# Altium schematic sheet Sheet1.SchDoc(Sheet1)
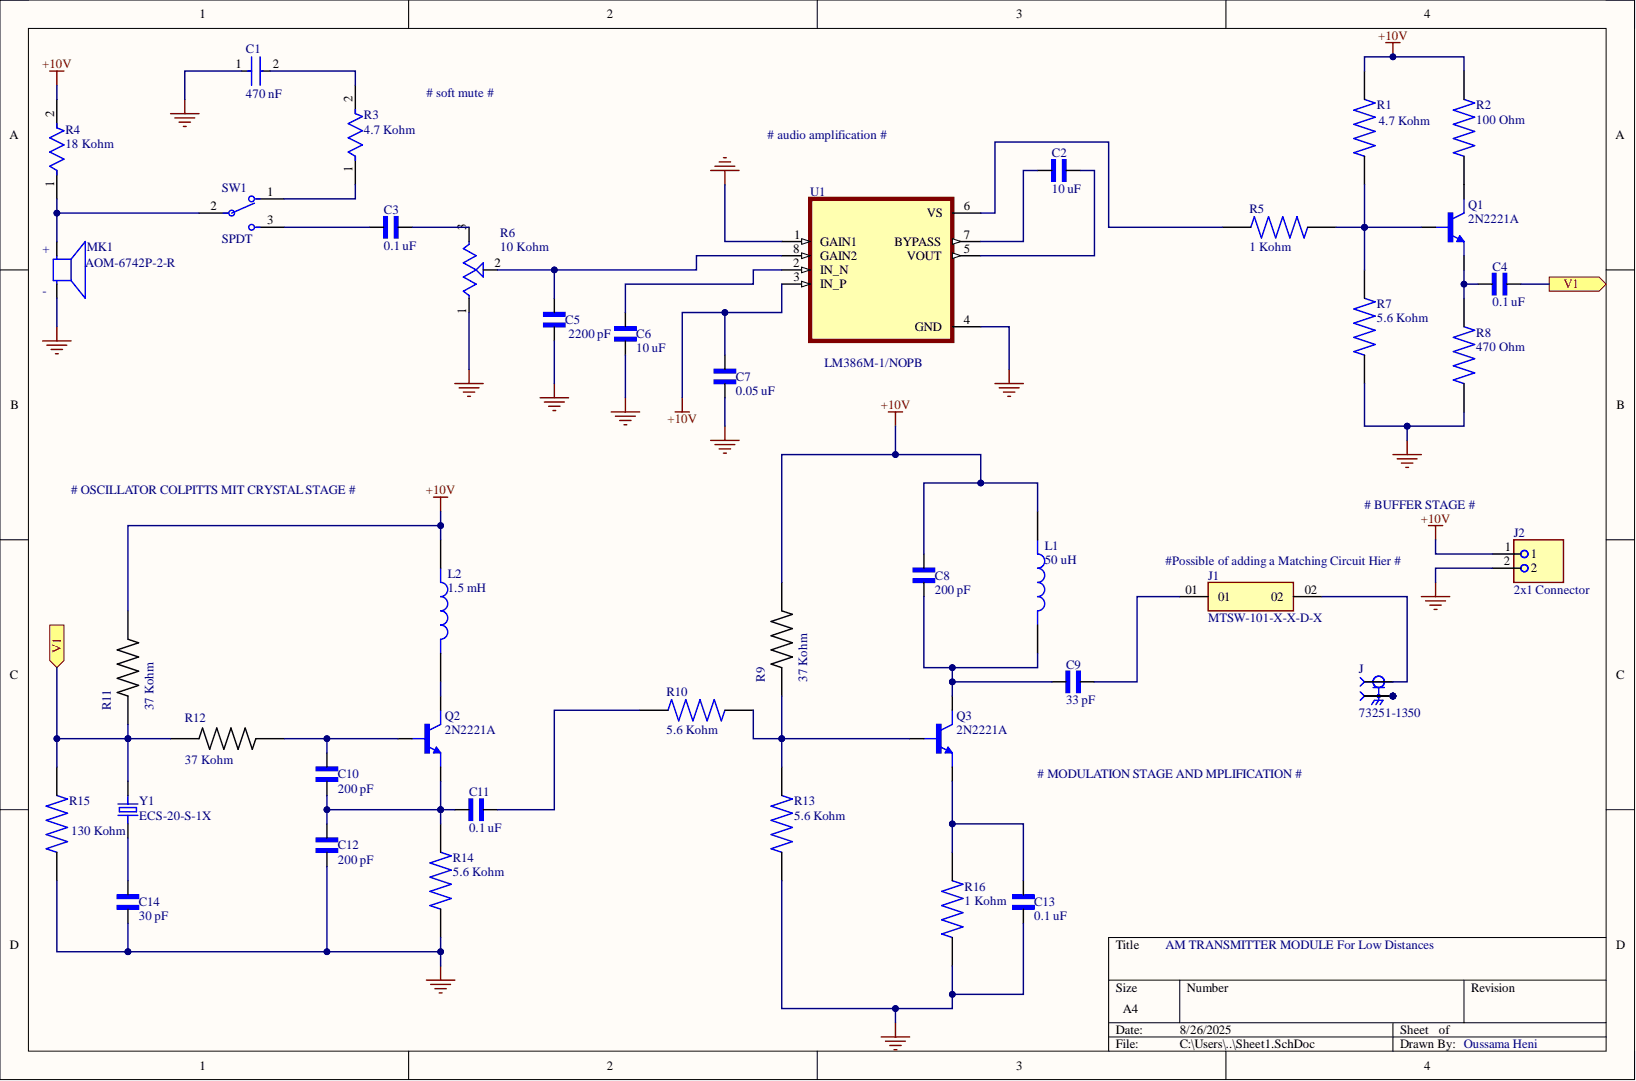
[diagram p0: Sheet1.SchDoc]

## Parts
C1  470 nF  [FP-0603-L_1_6_0_15-W_0_81-IPC_B]
C2  10 uF  [CAPC2012X140N]
C3  0.1 uF  [CAPRR750W60L1260T450H1390]
C4  0.1 uF  [CAPRR750W60L1260T450H1390]
C5  2200 pF  [CAPC1608X90N]
C6  10 uF  [CAPC2012X140N]
C7  0.05 uF  [CAPC3216X94N]
C8  200 pF  [CAPC1608X90N]
C9  33 pF  [CAPC1608X90]
C10  200 pF  [CAPC1608X90N]
C11  0.1 uF  [CAPRR750W60L1260T450H1390]
C12  200 pF  [CAPC1608X90N]
C13  0.1 uF  [CAPRR750W60L1260T450H1390]
C14  30 pF  [CAPC1608X90]
J  73251-1350  [MOLEX_73251-1350]
J1  MTSW-101-X-X-D-X  [SAMTEC_MTSW-101-X-X-D-X]
J2  2x1 Connector  [PHOENIX_1729128]
L1  50 uH  [INDAD2250W80L2000D550]
L2  1.5 mH  [INDAD1680W80L1200D650]
MK1  AOM-6742P-2-R  [MIC_AOM-6742P-2-R]
Q1  2N2221A  [TO-18]
Q2  2N2221A  [TO-18]
Q3  2N2221A  [TO-18]
R1  4.7 Kohm  [RESC3116X65N]
R2  100 Ohm  [RES_ERJPA3F1000V]
R3  4.7 Kohm  [FP-CRCW0603-e3-IPC_C]
R4  18 Kohm  [FP-RT1206-IPC_C]
R5  1 Kohm  [RESC3116X65N]
R6  10 Kohm  [TRIM_PVG3G103C01R00]
R7  5.6 Kohm  [RES_ERJ8ENF5601V]
R8  470 Ohm  [RES_ERJPA2D4700X]
R9  37 Kohm  [*RESC3216X70N]
R10  5.6 Kohm  [RES_ERJ8ENF5601V]
R11  37 Kohm  [*RESC3216X70N]
R12  37 Kohm  [*RESC3216X70N]
R13  5.6 Kohm  [RES_ERJ8ENF5601V]
R14  5.6 Kohm  [RES_ERJ8ENF5601V]
R15  130 Kohm  [RESC3216X65N]
R16  1 Kohm  [RESC3116X65N]
SW1  SPDT  [FP-JS102011JCQN-MFG]
U1  LM386M-1/NOPB  [SOIC127P599X175-8N]
Y1  ECS-20-S-1X  [XTAL_ECS-20-S-1X]

## Ports
V1

## Nets
+10V: C7.2 C8.2 J2.1 L1.1 L2.1 R1.2 R2.2 R4.2 R9.2 R11.2 U1.3(IN_P)
GND: C1.1 C5.1 C6.1 C7.1 C12.1 C13.1 C14.2 J2.2 MK1.N R6.1 R7.2 R8.1 R13.1 R14.1 R15.1 R16.1 U1.1(GAIN1) U1.4(GND)
V1: C4.2 R11.1 R12.1 R15.2 Y1.2 ; port V1
NetC1_2: C1.2 R3.2
NetC2_1: C2.1 U1.7(BYPASS)
NetC2_2: C2.2 U1.5(VOUT)
NetC3_1: C3.1 SW1.3
NetC3_2: C3.2 R6.3
NetC4_1: C4.1 Q1.1 R8.2
NetC5_2: C5.2 R6.2 U1.8(GAIN2)
NetC6_2: C6.2 U1.2(IN_N)
NetC8_1: C8.1 C9.2 L1.2 Q3.3
NetC9_1: C9.1 J1.01
NetC10_1: C10.1 C11.1 C12.2 Q2.1 R14.2
NetC10_2: C10.2 Q2.2 R12.2
NetC11_2: C11.2 R10.1
NetC13_2: C13.2 Q3.1 R16.2
NetC14_1: C14.1 Y1.1
NetJ1_02: J.1 J1.02
NetJ_S1: J.S1 J.S2 J.S3 J.S4
NetL2_2: L2.2 Q2.3
NetMK1_P: MK1.P R4.1 SW1.2
NetQ1_2: Q1.2 R1.1 R5.2 R7.1
NetQ1_3: Q1.3 R2.1
NetQ3_2: Q3.2 R9.1 R10.2 R13.2
NetR3_1: R3.1 SW1.1
NetR5_1: R5.1 U1.6(VS)

## Library data of the parts
C1 = CMP-1035-02703-3: General Purpose Ceramic Capacitor, 0603, 470nF, 10%, X7R, 15%, 25V; Capacitance: 470nF; Case Code (Imperial): 0603; Case Code (Metric): 1608; Case/Package: 0603; Component Kind: Standard; Construction: Flat; Depth: 0.81mm; Dielectric: X7R; Dielectric Material: Ceramic; Height: 0.94mm; Lead Free: Lead Free; Length: 1.6mm; Library Name: C 470n.SchLib; Max Operating Temperature: 125°C; Min Operating Temperature: -55°C; Mount: Surface Mount; Number of Pins: 2; Package Quantity: 1; Packaging: Tape and Reel; Radiation Hardening: No; REACH SVHC: No SVHC; RoHS Compliant: Yes; Series: -; Termination: SMD/SMT; Thickness: 0.035inch; Tolerance: 10%; Voltage: 25V; Voltage Rating: 25V; Voltage Rating (DC): 25V; Width: 0.032inch
C2 C6 = CL21A106KOQNNNG: 10 ÂµF Â±10% 16V Ceramic Capacitor X5R 0805 (2012 Metric); MF: Samsung Electro-Mechanics; Check_prices: https://www.snapeda.com/parts/CL21A106KOQNNNG/Samsung/view-part/?ref=eda; Component Kind: Standard; Library Name: 10 uf.SchLib; MP: CL21A106KOQNNNG; Package: SMD-2 Samsung; SnapEDA_Link: https://www.snapeda.com/parts/CL21A106KOQNNNG/Samsung/view-part/?ref=snap
C3 C4 C11 C13 = ECWFD2W104K4: CAP FILM 0.1UF 10% 450VDC RADIAL; MF: Panasonic; MP: ECW-FD2W104K4; Check_prices: https://www.snapeda.com/parts/ECW-FD2W104K4/Panasonic/view-part/?ref=eda; Component Kind: Standard; Library Name: C 0.1u.SchLib; Package: RADIAL-2 Panasonic; SnapEDA_Link: https://www.snapeda.com/parts/ECW-FD2W104K4/Panasonic/view-part/?ref=snap
C5 C8 C10 C12 = GRM1885C2A222JA01D: MF: {'manufacturer': u'Murata Electronics'}; Availability: Unavailable; Component Kind: Standard; Library Name: 200pf.SchLib; MP: GRM1885C2A222JA01D; Package: None; Price: None
C7 = 12065C503JAT2A: 0.05 ÂµF Â±5% 50V Ceramic Capacitor X7R 1206 (3216 Metric); MF: AVX; Check_prices: https://www.snapeda.com/parts/12065C503JAT2A/AVX/view-part/?ref=eda; Component Kind: Standard; Library Name: 0.05 uf.SchLib; MP: 12065C503JAT2A; Package: 3216 AVX; SnapEDA_Link: https://www.snapeda.com/parts/12065C503JAT2A/AVX/view-part/?ref=snap
C9 C14 = CC0603FRNPO9BN300: 0603 30 pF 50 V Â±1 % Tolerance NP0 SMT Multilayer Ceramic Capacitor; MF: Yageo; Check_prices: https://www.snapeda.com/parts/CC0603FRNPO9BN300/Yageo/view-part/?ref=eda; Component Kind: Standard; Library Name: 30 pFF.SchLib; MP: CC0603FRNPO9BN300; Package: 0603 Yageo; SnapEDA_Link: https://www.snapeda.com/parts/CC0603FRNPO9BN300/Yageo/view-part/?ref=snap
J = 73251-1350: SMA Connector Jack, Female Socket 50Ohm Surface Mount Solder; MF: Molex; Availability: Warning; Component Kind: Standard; Library Name: 73251-1350.SchLib; Mouser-Purchase-URL: https://snapeda.com/shop?store=Mouser&id=504767; MP: 73251-1350; Package: None; Price: None; SnapEDA_Link: https://www.snapeda.com/parts/73251-1350/Molex/view-part/504767/?ref=snap
J1 = MTSW-101-X-X-D-X: Variable Height PCB Header Strips, 0.100 pitch; MF: SAMTEC; MP: MTSW-101-09-L-D-210; Check_prices: https://www.snapeda.com/parts/MTSW-101-09-L-D-210/Samtec/view-part/?ref=eda; Component Kind: Standard; Library Name: MTSW-101-X-X-D-X.SchLib; Package: None; SnapEDA_Link: https://www.snapeda.com/parts/MTSW-101-09-L-D-210/Samtec/view-part/?ref=snap
J2 = 1729128: PCB Terminal Screw 5.08mm 16AWG 400V 13.5A 2 Position COMBICON MKDSN Series | Phoenix Contact 1729128; Check_prices: https://www.snapeda.com/parts/1729128/Phoenix+Contact/view-part/?ref=eda; Component Kind: Standard; Library Name: connector 2 pins.SchLib; MF: Phoenix Contact; MP: 1729128; Package: None; SnapEDA_Link: https://www.snapeda.com/parts/1729128/Phoenix+Contact/view-part/?ref=snap
L1 = B82132A5401M000: Availability: Unavailable; Component Kind: Standard; Library Name: 50mH.SchLib; MP: B82132A5401M000; Package: None; Price: None
L2 = B82145A1155J000: Axial Leaded High Frequency Inductor, RF Choke, B82145A HLBC Series, 1.5 mH, 5%, 5.4 ohm, 300 mA; MF: EPCOS; Check_prices: https://www.snapeda.com/parts/B82145A1155J000/EPCOS/view-part/?ref=eda; Component Kind: Standard; Library Name: 1.5 mH.SchLib; MP: B82145A1155J000; Package: None; SnapEDA_Link: https://www.snapeda.com/parts/B82145A1155J000/EPCOS/view-part/?ref=snap
MK1 = AOM-6742P-2-R: MICROPHONE -42DB 1.5VDC; MF: PUI Audio; Check_prices: https://www.snapeda.com/parts/AOM-6742P-2-R/PUI+Audio/view-part/?ref=eda; Component Kind: Standard; Library Name: AOM-6742P-2-R.SchLib; MP: AOM-6742P-2-R; Package: PUI Audio; SnapEDA_Link: https://www.snapeda.com/parts/AOM-6742P-2-R/PUI+Audio/view-part/?ref=snap
Q1-Q3 = 2N2221A: Bipolar (BJT) Transistor NPN 40 V 800 mA 250MHz 1.8 W Through Hole TO-18; MF: Central Semiconductor; Check_prices: https://www.snapeda.com/parts/2N2221A/Central+Components+Manufacturing/view-part/?ref=eda; Component Kind: Standard; Library Name: 2N2221A.SchLib; MP: 2N2221A; Package: TO-18 Microsemi; SnapEDA_Link: https://www.snapeda.com/parts/2N2221A/Central+Components+Manufacturing/view-part/?ref=snap
R1 = RC1206JR-074K7L: 4.7 kOhms Â±5% 0.25W, 1/4W Chip Resistor 1206 (3216 Metric) Moisture Resistant Thick Film; MF: Yageo; Check_prices: https://www.snapeda.com/parts/RC1206JR-074K7L/Yageo/view-part/?ref=eda; Component Kind: Standard; Library Name: 4.7 k ohm.SchLib; MP: RC1206JR-074K7L; Package: 3116 Yageo; SnapEDA_Link: https://www.snapeda.com/parts/RC1206JR-074K7L/Yageo/view-part/?ref=snap
R2 = ERJPA3F1000V: Thick Film Smnt Resistor 0603 Case 100Ohm +/-1% 0.25W +/-100ppm/degC | Panasonic Electronic Components ERJPA3F1000V; Check_prices: https://www.snapeda.com/parts/ERJPA3F1000V/Panasonic/view-part/?ref=eda; Component Kind: Standard; Library Name: 100ohm.SchLib; MF: Panasonic; MP: ERJPA3F1000V; Package: 1608-2 Panasonic; SnapEDA_Link: https://www.snapeda.com/parts/ERJPA3F1000V/Panasonic/view-part/?ref=snap
R3 = CMP-2000-03413-2: RES Thick Film, 4.7kΩ, 5%, 0.1W, 200ppm/°C, 0603; Max Power Dissipation: 100mW; Resistance: 4.7kR; Voltage Rating: 75V; Case Code (Imperial): 0603; Case Code (Metric): 1608; Case/Package: 0603; Component Kind: Standard; Composition: Thick Film; Contact Plating: Tin; Depth: 0.85mm; Features: Automotive AEC-Q200; Height: 0.45mm; Lead Free: Lead Free; Length: 1.55mm; Library Name: R 4.7K.SchLib; Max Operating Temperature: 155°C; Military Standard: Not; Min Operating Temperature: -55°C; Mount: Surface Mount; Number of Terminations: 2; Package Quantity: 1; Packaging: Tape and Reel; Power Rating: 100mW; Radiation Hardening: No; Temperature Coefficient: 200ppm/°C; Termination: SMD/SMT; Tolerance: 5%; Voltage Rating (DC): 75V; Weight: 7.1E-05oz; Width: 0.85mm
R4 = CMP-03412-016818-1: RES SMD 18K OHM 0.1% 1/4W 1206; Manufacturer: Yageo; Manufacturer Part Number: RT1206BRD0718KL; Power Rating: 0.25W; Resistance: 18KΩ; Temperature Coefficient: 25 ppm/°C; Tolerance: 0.005; Value: 18KΩ; Voltage Rating: 200V; Automotive: No; Category: Res; Component Kind: Standard; ComponentLink2Description: Datasheet; ComponentLink2URL: http://www.yageo.com/documents/recent/PYu-RT_1-to-0.01_RoHS_L_9.pdf; Composition: Thin Film; Device Class L1: Passive Components; Device Class L2: Resistors; Device Class L3: Chip SMD Resistors; Digikey Description: RES SMD 18K OHM 0.1% 1/4W 1206; Footprint Url: http://www.yageo.com/exep/pages/download/literatures/PYu-R_Mount_10.pdf; Height: 0.65mm; Ipc Land Pattern Name: RESC310160X55; Lead Free: Yes; Library Name: Components - 22.08.25 - 21.18.SchLib; Mouser Description: Res SMD 18K Ohm 0.1% 1/8W 1206; Octopart: https://octopart.com/rt1206brd0718kl-yageo-1209828; Package: RT1206; RoHS: TRUE; Series: RT; Temperature: -55°C to +155°C; Temperature Range High: +155°C; Temperature Range Low: -55°C
R5 R16 = RC1206FR-071KL: 1 kOhms Â±1% 0.25W, 1/4W Chip Resistor 1206 (3216 Metric) Moisture Resistant Thick Film; MF: Yageo; Check_prices: https://www.snapeda.com/parts/RC1206FR-071KL/Yageo/view-part/?ref=eda; Component Kind: Standard; Library Name: 1 kohm.SchLib; MP: RC1206FR-071KL; Package: 3116 Yageo; SnapEDA_Link: https://www.snapeda.com/parts/RC1206FR-071KL/Yageo/view-part/?ref=snap
R6 = PVG3G103C01R00: 10 kOhms 0.25W, 1/4W Gull Wing Surface Mount Trimmer Potentiometer Cermet 1.0 Turn Top Adjustment; MF: Bourns; Check_prices: https://www.snapeda.com/parts/PVG3G103C01R00/Bourns/view-part/?ref=eda; Component Kind: Standard; Library Name: potent 10k 0.25 w.SchLib; MP: PVG3G103C01R00; Package: SMD-3 Bourns; SnapEDA_Link: https://www.snapeda.com/parts/PVG3G103C01R00/Bourns/view-part/?ref=snap
R7 R10 R13 R14 = ERJ8ENF5601V: Availability: Unavailable; Component Kind: Standard; Library Name: 5.6 k ohm.SchLib; MP: ERJ8ENF5601V; Package: None; Price: None
R8 = ERJPA2D4700X: Availability: Unavailable; Component Kind: Standard; Library Name: 470 ohm.SchLib; MP: ERJPA2D4700X; Package: None; Price: None
R9 R11 R12 = RN73H2BTTD3702F100: Check_prices: https://www.snapeda.com/parts/RN73H2BTTD3702F100/KOA+Speer/view-part/?ref=eda; Component Kind: Standard; Library Name: 37 kohm.SchLib; SnapEDA_Link: https://www.snapeda.com/parts/RN73H2BTTD3702F100/KOA+Speer/view-part/?ref=snap
R15 = MCR18EZPJ134: Res Thick Film 1206 130K Ohm 5% 0.25W(1/4W) Â±200ppm/Â°C Pad SMD Automotive T/R; MF: Rohm; Check_prices: https://www.snapeda.com/parts/MCR18EZPJ134/Rohm/view-part/?ref=eda; Component Kind: Standard; Library Name: res130k.SchLib; MP: MCR18EZPJ134; Package: 3216 Rohm Semiconductor; SnapEDA_Link: https://www.snapeda.com/parts/MCR18EZPJ134/Rohm/view-part/?ref=snap
SW1 = CMP-10038-000010-1: SWITCH SLIDE SPDT 300MA 6V; Actuator Length: 2mm; Actuator Material: Nylon; Actuator Type: Standard; Electrical Life: 5000Cycles; Switch Function: On-On; Component Kind: Standard; Contact Material: Copper Alloy; Contact Plating: Silver; Current Rating: 300mA; Library Name: Components - 22.08.25 - 20.54.SchLib; Max Operating Temperature: 85°C; Min Operating Temperature: -40°C; Mount: Surface Mount; Packaging: Cut Tape; Switch Travel: 2mm; Throw Configuration: SPDT; Voltage Rating (DC): 6V
U1 = LM386M-1/NOPB: 700-mW, mono, 5- to 18-V, analog input Class-AB audio amplifier; MF: Texas Instruments; Check_prices: https://www.snapeda.com/parts/LM386M-1/NOPB/Texas+Instruments/view-part/?ref=eda; Component Kind: Standard; Library Name: LM386M-1_NOPB.SchLib; MP: LM386M-1/NOPB; Package: SOIC-8 Texas Instruments; SnapEDA_Link: https://www.snapeda.com/parts/LM386M-1/NOPB/Texas+Instruments/view-part/?ref=snap
Y1 = ECS-20-S-1X: 2 MHz Â±30ppm Crystal Series 500 Ohms HC-49/U; MF: ECS Inc.; Check_prices: https://www.snapeda.com/parts/ECS-20-S-1X/ECS/view-part/?ref=eda; Component Kind: Standard; Library Name: ECS-20-S-1X.SchLib; MP: ECS-20-S-1X; Package: HC-49UX-1X-2 ECS; SnapEDA_Link: https://www.snapeda.com/parts/ECS-20-S-1X/ECS/view-part/?ref=snap
